# Altium schematic sheet top.SchDoc
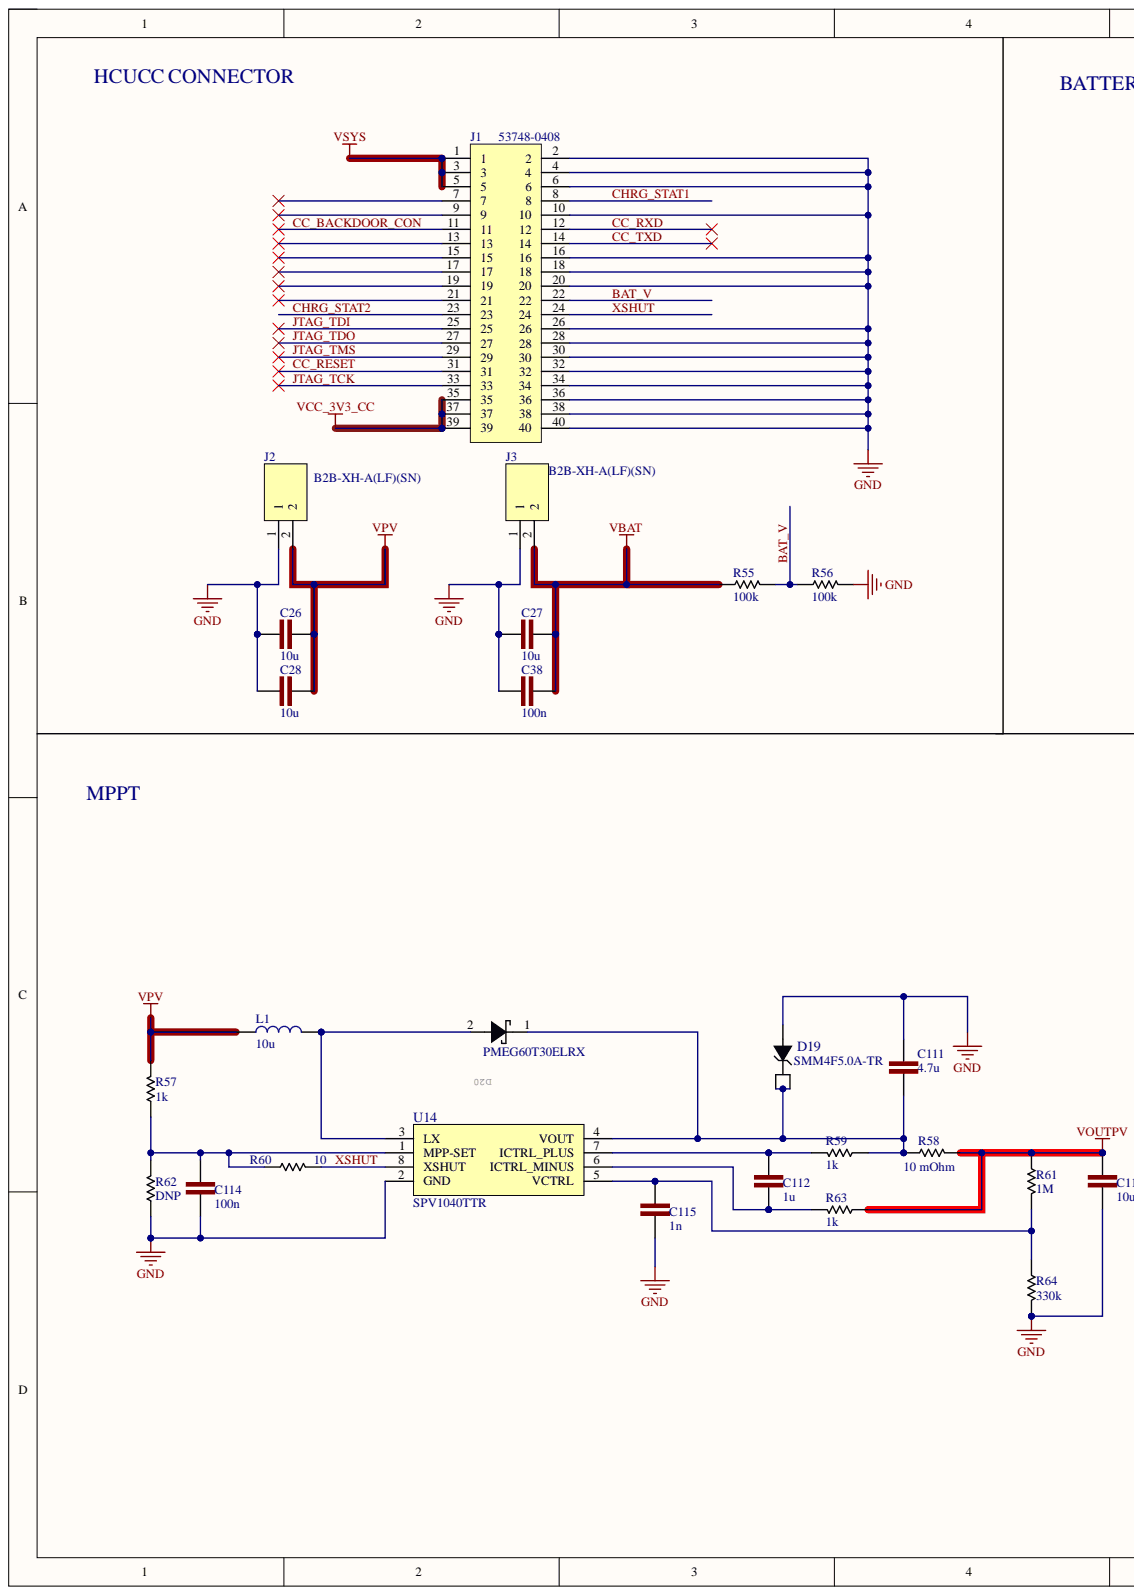
[diagram p0: top.SchDoc, left]
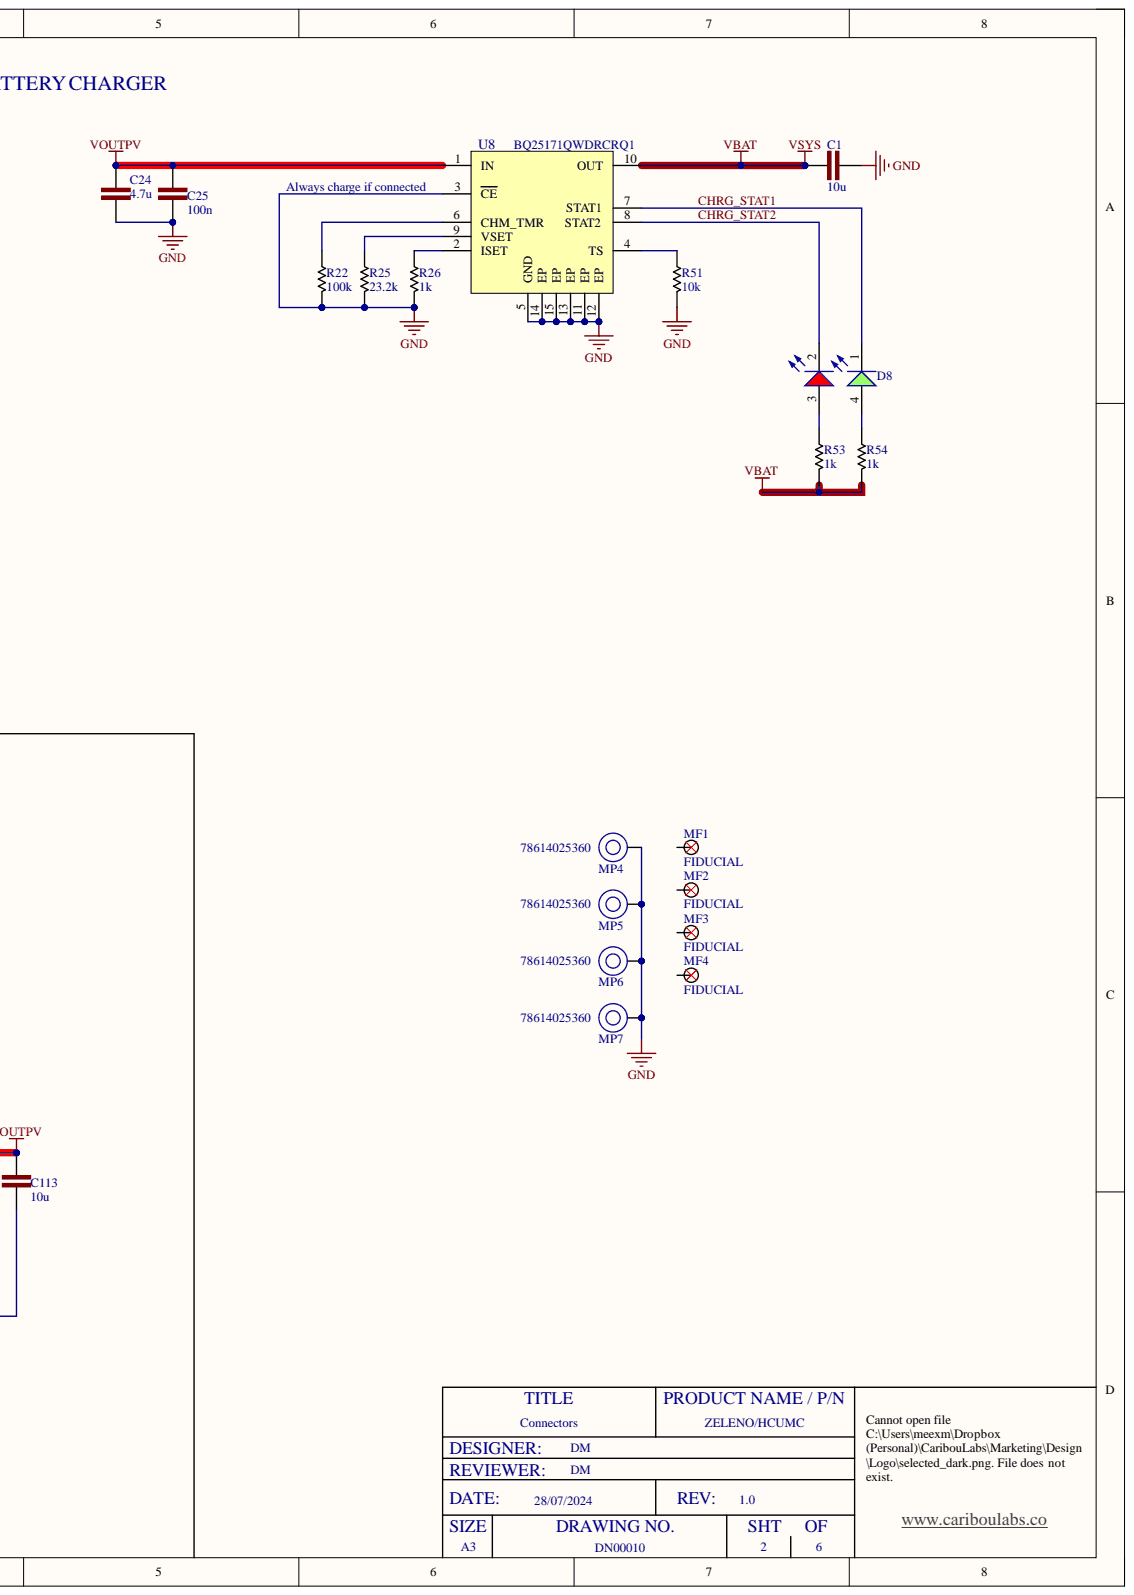
[diagram p1: top.SchDoc, right]

## Parts
C1  10u  [MURATA 0603]
C24  4.7u  [MURATA 0402]
C25  100n  [MURATA 0402]
C26  10u  [MURATA 0603]
C27  10u  [MURATA 0603]
C28  10u  [MURATA 0603]
C38  100n  [MURATA 0402]
C111  4.7u  [MURATA 0402]
C112  1u  [MURATA 0402]
C113  10u  [MURATA 0603]
C114  100n  [MURATA 0402]
C115  1n  [MURATA 0402]
D8  Red & Bright Green, 2V, 140°  [WL-SBCC_0603]  (value not drawn)
D19  SMM4F5.0A-TR  [SMM4F15ATR]
D20  PMEG60T30ELRX  [SODFL3517X110N]
J1  53748-0408  [537480408]
J2  B2B-XH-A(LF)(SN)  [JST_B2B-XH-A(LF)(SN)]
J3  B2B-XH-A(LF)(SN)  [JST_B2B-XH-A(LF)(SN)]
L1  10u  [XAL6060-103MEB]
MF1  FIDUCIAL  [FIDUCIAL_1_0_MM]
MF2  FIDUCIAL  [FIDUCIAL_1_0_MM]
MF3  FIDUCIAL  [FIDUCIAL_1_0_MM]
MF4  FIDUCIAL  [FIDUCIAL_1_0_MM]
MP4  M3 x 2.5mm  [78614025360]  (value not drawn)
MP5  M3 x 2.5mm  [78614025360]  (value not drawn)
MP6  M3 x 2.5mm  [78614025360]  (value not drawn)
MP7  M3 x 2.5mm  [78614025360]  (value not drawn)
R22  100k  [PANASONIC 0402]
R25  23.2k  [PANASONIC 0402]
R26  1k  [PANASONIC 0402]
R51  10k  [PANASONIC 0402]
R53  1k  [PANASONIC 0402]
R54  1k  [PANASONIC 0402]
R55  100k  [PANASONIC 0402]
R56  100k  [PANASONIC 0402]
R57  1k  [PANASONIC 0402]
R58  10 mOhm  [RES_ERJ6CW]
R59  1k  [PANASONIC 0402]
R60  10  [PANASONIC 0402]
R61  1M  [PANASONIC 0402]
R62  DNP  [PANASONIC 0402]  (no BOM)
R63  1k  [PANASONIC 0402]
R64  330k  [PANASONIC 0402]
U8  BQ25171QWDRCRQ1  [BQ25171QWDRCRQ1]
U14  SPV1040TTR  [SOP65P640X120-8N]

## Nets
GND: C1.1 C24.2 C25.2 C26.2 C27.2 C28.2 C38.1 C111.1 C113.2 C114.2 C115.1 D19.2 J1.2 J1.4 J1.6 J1.10 J1.16 J1.18 J1.20 J1.26 J1.28 J1.30 J1.32 J1.34 J1.36 J1.38 J1.40 J2.1 J3.1 MP4.1 MP5.1 MP6.1 MP7.1 R22.2 R25.2 R26.2 R51.2 R56.2 R62.1 R64.2 U8.3(CE) U8.5(GND) U8.11(EP) U8.12(EP) U8.13(EP) U8.14(EP) U8.15(EP) U14.2(GND)
VBAT: C1.2 C27.1 C38.2 J1.1 J1.3 J1.5 J3.2 R53.2 R54.2 R55.1 U8.10(OUT)
VCC_3V3_CC: J1.35 J1.37 J1.39
VOUTPV: C24.1 C25.1 C113.1 R58.2 R61.1 R63.1 U8.1(IN)
VPV: C26.1 C28.1 J2.2 L1.1 R57.2
BAT_V: J1.22 R55.2 R56.1
CC_BACKDOOR_CON: J1.11
CC_RESET: J1.31
CC_RXD: J1.12
CC_TXD: J1.14
CHRG_STAT1: D8.1 J1.8 U8.7(STAT1)
CHRG_STAT2: D8.2 J1.23 U8.8(STAT2)
JTAG_TCK: J1.33
JTAG_TDI: J1.25
JTAG_TDO: J1.27
JTAG_TMS: J1.29
XSHUT: J1.24 R60.2 U14.8(XSHUT)
NetC111_2: C111.2 D19.1 D19.3 D20.1 R58.1 R59.1 U14.4(VOUT)
NetC112_1: C112.1 R59.2 U14.7(ICTRL_PLUS)
NetC112_2: C112.2 R63.2 U14.6(ICTRL_MINUS)
NetC114_1: C114.1 R57.1 R60.1 R62.2 U14.1(MPP-SET)
NetC115_2: C115.2 R61.2 R64.1 U14.5(VCTRL)
NetD8_3: D8.3 R53.1
NetD8_4: D8.4 R54.1
NetD20_2: D20.2 L1.2 U14.3(LX)
NetR22_1: R22.1 U8.6(CHM_TMR)
NetR25_1: R25.1 U8.9(VSET)
NetR26_1: R26.1 U8.2(ISET)
NetR51_1: R51.1 U8.4(TS)

Not connected: J1 7 9 13 15 17 19 21; MF1 1; MF2 1; MF3 1; MF4 1

## Library data of the parts
C1 C26-C28 C113 = Capacitor: Multilayer Ceramic Capacitors MLCC - SMD/SMT 10 uF 35 VDC 20% 0603 X5R; Initial Voltage: 0; Manu. P/N: GRM188R6YA106MA73D; SRF: TBD [GHz]; Temp. Range: [-40, 125]; Vmax: 35V; Component Kind: Standard; Library Name: DM_Lib.IntLib; Simulation: Model Name; Technology: X5R; Tolerance: 20%; Value: 10u
C24 C111 = Capacitor: Multilayer Ceramic Capacitors MLCC - SMD/SMT 0402 MLCC X5R 4.7 uF +/ -20% 10V; Initial Voltage: 0; Manu. P/N: GRJ155R61A475ME11J; SRF: TBD [GHz]; Temp. Range: [-55, 85]; Tolerance: 20%; Component Kind: Standard; Library Name: CuSiP_Lib.IntLib; Signal Integrity: Guessed Model; Simulation: Model Name; Technology: X5R; VALUE: 4.7u; Vmax: 10V
C25 C38 C114 = Capacitor: Multilayer Ceramic Capacitors MLCC - SMD/SMT 0.1 uF 50 VDC 10% 0402 X7R AEC-Q200; Initial Voltage: 0; Manu. P/N: GRT155R71H104KE01D; SRF: TBD [GHz]; Temp. Range: [-40, 125]; Vmax: 50V; Component Kind: Standard; Library Name: DM_Lib.IntLib; Simulation: Model Name; Technology: X5R; Tolerance: 10%; Value: 100n
C112 = Capacitor: Multilayer Ceramic Capacitors MLCC - SMD/SMT 1 uF 50 VDC 10% 0402 X5R; Initial Voltage: 0; Manu. P/N: GRM155R61H105KE05D; SRF: TBD [GHz]; Temp. Range: [-40, 125]; Tolerance: 20%; Vmax: 50V; Component Kind: Standard; Library Name: DM_Lib.IntLib; Signal Integrity: Guessed Model; Simulation: Model Name; Technology: X5R; VALUE: 1u
C115 = Capacitor: Multilayer Ceramic Capacitors MLCC 1000pF ±5% 100V C0G 0402; Initial Voltage: 0; Manu. P/N: GRM1555C2A102JE01D; SRF: TBD [GHz]; Temp. Range: [-40, 125]; Component Kind: Standard; Library Name: DM_Lib.IntLib; Signal Integrity: Guessed Model; Simulation: Model Name; Technology: C0G; Tolerance: 5%; VALUE: 1n; Vmax: 100V
D8 = 150060RV75240: WL-SBCC SMT Bi-color Chip LED Compact, size 0603, Red & Bright Green, 2V, 140°; Manufacturer: Wurth Elektronik; Case/Size Code: 0603; Chip Technology: AlInGaP; Dominant Wavelength (Bright Green): 570nm; Dominant Wavelength (Red): 625nm; Luminous Intensity (Bright Green): 90mcd; Luminous Intensity (Red): 230mcd; Peak Wavelength (Bright Green): 572nm; Peak Wavelength (Red): 630nm; Category: Signal LEDs; Component Kind: Standard; ComponentLink1Description: Website Link; ComponentLink1URL: https://www.we-online.com/redexpert/article/150060RV75240?ad; ComponentLink2Description: Datasheet Link; ComponentLink2URL: https://www.we-online.com/redexpert/spec/150060RV75240?ad; Emitting Color: Red & Bright Green; Forward Voltage (Bright Green): 2V; Forward Voltage (Red): 2V; Height: 0.4mm; Length: 1.6mm; Library Name: DM_Lib.IntLib; Manu. P/N: 150060RV75240; Match Code: WL-SBCC; Mount: Surface Mount; Operating Temperature Max: 85°C; Operating Temperature Min: -40°C; Packaging: Tape and Reel; Simulation: 0603_150060RV75240; Viewing Angle: 140°; Width: 0.8mm
D19 = SMM4F5.0A-TR: ESD Suppressors / TVS Diodes 400W HI JCT TMP; Component Kind: Standard; Library Name: DM_Lib.IntLib; Manu. P/N: SMM4F5.0A-TR
D20 = PMEG60T30ELRX: 60 V, 3 A low leakage current Trench MEGA Schottky barrier rectifier; Component Kind: Standard; Library Name: DM_Lib.IntLib; Manu. P/N: PMEG60T30ELRX
J1 = 53748-0408: Molex B2B 40 Pos, 0.5mm pitch, stack-height 3 mm, mates with 52991-0408; Component Kind: Standard; Library Name: DM_Lib.IntLib; Manu. P/N: 53748-0408
J2 J3 = B2B-XH-A(LF)(SN): Connector Header Through Hole 2 position 0.098 (2.50mm); Component Kind: Standard; Library Name: DM_Lib.IntLib; Manu. P/N: B2B-XH-A(LF)(SN)
L1 = Inductor: Power Inductors - SMD 10uH Shld 20% 7A 29.82mOhms AECQ2; DC Imax: 7A (7.6 Asat); Manu. P/N: XAL6060-103MEC; SRF: 14 MHz; Component Kind: Standard; DC Rmax: 29.82 mOhms; Library Name: DM_Lib.IntLib; Simulation: Model Name; Tolerance: 20%; Value: 10u
MP4-MP7 = 78614025360: WE-SMSA SMT Spacer Studs Automotive, OD 6mm, ID 4.2mm, M3 x 2.5mm; Manufacturer: Wurth Elektronik; Solder Cream Thickness Min: 150µm; Surface: Tin; Tightenning Torque Max: 0.5N*m; Category: Assembly; Component Kind: Standard; ComponentLink1Description: Website Link; ComponentLink1URL: https://www.we-online.com/redexpert/article/78614025360?ad; ComponentLink2Description: Datasheet Link; ComponentLink2URL: https://www.we-online.com/redexpert/spec/78614025360?ad; Height: 2.5mm; Inner Diameter: 4.2mm; Library Name: DM_Lib.IntLib; Manu. P/N: 78614025360; Match Code: WE-SMSA; Material: Steel; Mount: Surface Mount; Operating Temperature Max: 150°C; Operating Temperature Min: -55°C; Outer Diameter: 6mm; Packaging: Tape and Reel; Thread: M3
R22 = Resistor: Thick Film Resistors - SMD 1/16watt 100Kohms 1%; Manu. P/N: CRCW0402100KFKED; Power Rating: 62.5 mW (1/16 W); Temp. Ceoff.: 100 PPM / C; Component Kind: Standard; Library Name: DM_Lib.IntLib; Signal Integrity: Guessed Model; Simulation: Model Name; Tolerance: 1%; VALUE: 100k
R25 = Resistor: Thick Film Resistors - SMD 1/16watt 23.2Kohms 1%; Manu. P/N: CRCW040223K2FKED; Power Rating: 62.5 mW (1/16 W); Temp. Ceoff.: 100 PPM / C; Component Kind: Standard; Library Name: DM_Lib.IntLib; Signal Integrity: Guessed Model; Simulation: Model Name; Tolerance: 1%; VALUE: 23.2k
R26 R53 R54 R57 R59 R63 = Resistor: Thick Film Resistors - SMD 1/16watt 1Kohms 1% Commercial Use; Manu. P/N: CRCW04021K00FKEDC; Power Rating: 62.5 mW (1/16 W); Temp. Ceoff.: 100 PPM / C; Component Kind: Standard; Library Name: DM_Lib.IntLib; Signal Integrity: Guessed Model; Simulation: Model Name; Tolerance: 1%; VALUE: 1k
R51 = Resistor: Thick Film Resistors - SMD 1/16watt 10Kohms 1% Commercial Use; Manu. P/N: CRCW040210K0FKEDC; Power Rating: 62.5 mW (1/16 W); Temp. Ceoff.: 100 PPM/C; Component Kind: Standard; Library Name: DM_Lib.IntLib; Signal Integrity: Guessed Model; Simulation: Model Name; Tolerance: 1%; VALUE: 10k
R55 R56 = Resistor: Thick Film Resistors - SMD 1/20watt 100Kohms 1% 200ppm; Manu. P/N: CRCW0201100KFKED; Power Rating: 50 mW (1/16 W); Temp. Ceoff.: 100 PPM / C; Component Kind: Standard; Library Name: DM_Lib.IntLib; Signal Integrity: Guessed Model; Simulation: Model Name; Tolerance: 1%; VALUE: 100k
R58 = Resistor: Current Sense Resistors - SMD 0805 0.5% 0.01ohm AEC-Q200; Manu. P/N: ERJ-6CWDR010V; Power Rating: 500mW; Temp. Ceoff.: 75 PPM/C; Component Kind: Standard; Library Name: DM_Lib.IntLib; Simulation: Model Name; Tolerance: 0.5%; Value: 10 mOhm
R60 = Resistor: Dale Thick Film Resistors - SMD 1/16watt 10ohms 1%; Manu. P/N: CRCW040210R0FKED; Power Rating: 62.5 mW (1/16 W); Temp. Ceoff.: 100 PPM / C; Component Kind: Standard; Library Name: DM_Lib.IntLib; Signal Integrity: Guessed Model; Simulation: Model Name; Tolerance: 1%; VALUE: 10
R61 = Resistor: Thick Film Resistors - SMD 1/16W 1Mohm .5%; Manu. P/N: CRCW04021M00DHEDP; Power Rating: 62.5 mW (1/16 W); Temp. Ceoff.: 50 PPM / C; Tolerance: 0.5%; Component Kind: Standard; Library Name: DM_Lib.IntLib; Signal Integrity: Guessed Model; Simulation: Model Name; VALUE: 1M
R62 = Resistor: Thick Film Resistors - SMD 1/20watt 1Kohms 1% 100ppm; Power Rating: 62.5 mW (1/16 W); Temp. Ceoff.: 100 PPM / C; Library Name: DM_Lib.IntLib; Manu. P/N: DNP; Signal Integrity: Guessed Model; Simulation: Model Name; Tolerance: 1%; VALUE: DNP
R64 = Resistor: Thick Film Resistors - SMD 1/16watt 330Kohms 1%; Manu. P/N: CRCW0402330KFKED; Power Rating: 62.5 mW (1/16 W); Temp. Ceoff.: 100 PPM / C; Component Kind: Standard; Library Name: DM_Lib.IntLib; Signal Integrity: Guessed Model; Simulation: Model Name; Tolerance: 1%; VALUE: 330k
U8 = BQ25171QWDRCRQ1: AUTOMOTIVE, 800-MA Linear Battery charger IC, 1-2-cell LiIon; Component Kind: Standard; Library Name: DM_Lib.IntLib; Manu. P/N: BQ25171QWDRCRQ1
U14 = SPV1040TTR: Switching Voltage Regulators Hi Eff Solar Charger MPPT 0.3V to 5.5V; Component Kind: Standard; Height: 1.2mm; Library Name: DM_Lib.IntLib; Manu. P/N: SPV1040TTR
MF1-MF4 = FIDUCIAL: Component Kind: Net Tie; Library Name: CuSiP_Lib.IntLib; MANU_PN: FIDUCIAL; Signal Integrity: Guessed Model
